# Altium schematic sheet Linux_Card.SchDoc
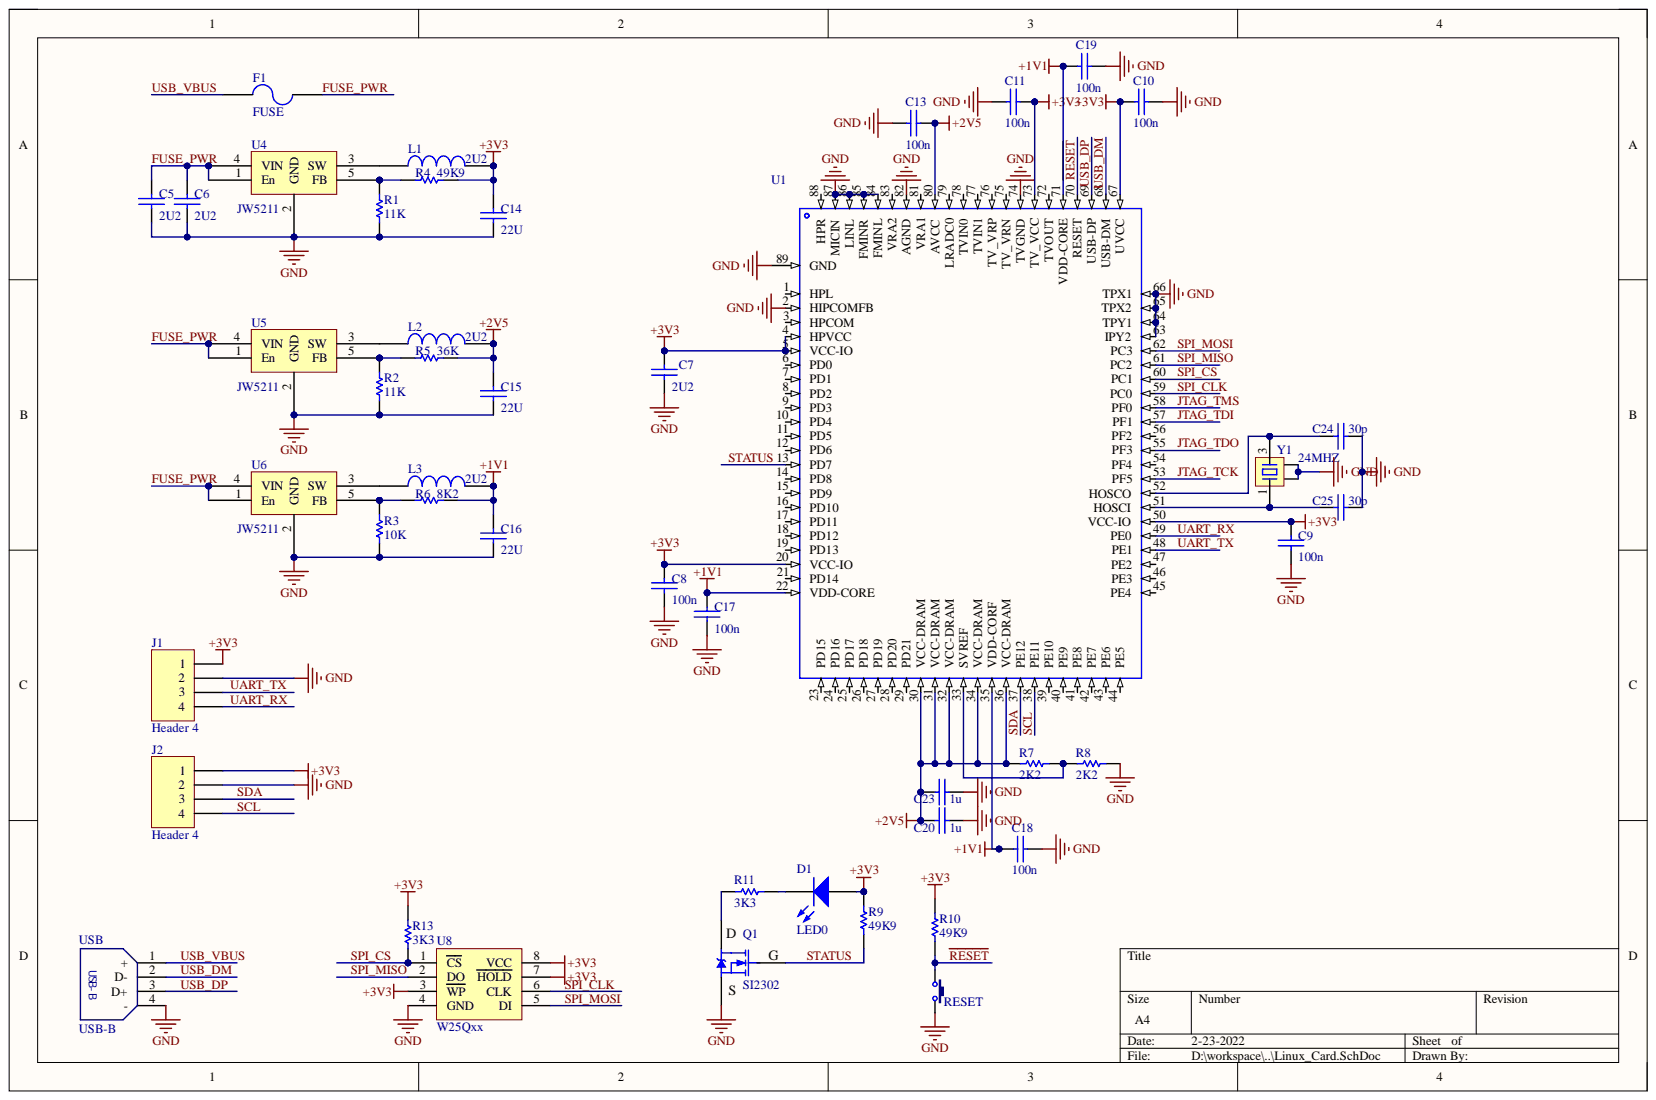
[diagram p0: Linux_Card.SchDoc]

## Parts
C5  2U2  [0603C]
C6  2U2  [0603C]
C7  2U2  [0603C]
C8  100n  [0603C]
C9  100n  [0603C]
C10  100n  [0603C]
C11  100n  [0603C]
C13  100n  [0603C]
C14  22U  [0603C]
C15  22U  [0603C]
C16  22U  [0603C]
C17  100n  [0603C]
C18  100n  [0603C]
C19  100n  [0603C]
C20  1u  [0603C]
C23  1u  [0603C]
C24  30p  [0603C]
C25  30p  [0603C]
D1  LED0  [LED 0805G]
F1  FUSE  [FU 0805]
J1  Header 4  [Uart]
J2  Header 4  [Uart]
L1  2U2  [0805L]
L2  2U2  [0805L]
L3  2U2  [0805L]
Q1  SI2302  [SOT23-3N]
R1  11K  [0603R]
R2  11K  [0603R]
R3  10K  [0603R]
R4  49K9  [0603R]
R5  36K  [0603R]
R6  8K2  [0603R]
R7  2K2  [0603R]
R8  2K2  [0603R]
R9  49K9  [0603R]
R10  49K9  [0603R]
R11  3K3  [0603R]
R13  3K3  [0603R]
RESET  3x6x5  [TSW SMD-3*6*2.5]  (value not drawn)
U1  (no value)  [QFN-88_L10.0-W10.0-P0.40-BL-EP6.75]
U4  JW5211  [SOT23-5N]
U5  JW5211  [SOT23-5N]
U6  JW5211  [SOT23-5N]
U8  W25Qxx  [SOP8W_N]
USB  USB-B  [USB]
Y1  24MHZ  [OSC 3225-4P]

## Nets
+1V1: C16.2 C17.2 C18.2 C19.2 L3.2 R6.1 U1.22(VDD-CORE) U1.35(VDD-CORF) U1.71(VDD-CORE)
+2V5: C13.2 C15.2 C20.2 C23.2 L2.2 R5.1 R7.1 U1.30(VCC-DRAM) U1.31(VCC-DRAM) U1.32(VCC-DRAM) U1.34(VCC-DRAM) U1.36(VCC-DRAM) U1.80(AVCC)
+3V3: C7.2 C8.2 C9.2 C10.2 C11.2 C14.2 D1.1 J1.1 J2.1 L1.2 R4.1 R9.2 R10.2 R13.2 U1.4(HPVCC) U1.5(VCC-IO) U1.20(VCC-IO) U1.50(VCC-IO) U1.67(UVCC) U1.73(TV_VCC) U8.3(WP) U8.7(HOLD) U8.8(VCC)
GND: C5.1 C6.1 C7.1 C8.1 C9.1 C10.1 C11.1 C13.1 C14.1 C15.1 C16.1 C17.1 C18.1 C19.1 C20.1 C23.1 C24.1 C25.1 J1.2 J2.2 Q1.2 R1.1 R2.1 R3.1 R8.2 RESET.2 U1.2(HIPCOMFB) U1.63(IPY2) U1.64(TPY1) U1.65(TPX2) U1.66(TPX1) U1.74(TVGND) U1.82(AGND) U1.84(FMINL) U1.85(FMINR) U1.86(LINL) U1.87(MICIN) U1.89(GND) U4.2(GND) U5.2(GND) U6.2(GND) U8.4(GND) USB.4(-) Y1.2 Y1.4
~{RESET}: R10.1 RESET.1 U1.70(RESET)
FUSE_PWR: C5.2 C6.2 F1.2 U4.1(En) U4.4(VIN) U5.1(En) U5.4(VIN) U6.1(En) U6.4(VIN)
JTAG_TCK: U1.53(PF5)
JTAG_TDI: U1.57(PF1)
JTAG_TDO: U1.55(PF3)
JTAG_TMS: U1.58(PF0)
SCL: J2.4 U1.38(PE11)
SDA: J2.3 U1.37(PE12)
SPI_CLK: U1.59(PC0) U8.6(CLK)
SPI_CS: R13.1 U1.60(PC1) U8.1(CS)
SPI_MISO: U1.61(PC2) U8.2(DO)
SPI_MOSI: U1.62(PC3) U8.5(DI)
STATUS: Q1.1 R9.1 U1.13(PD7)
UART_RX: J1.4 U1.49(PE0)
UART_TX: J1.3 U1.48(PE1)
USB_DM: U1.68(USB-DM) USB.2(D-)
USB_DP: U1.69(USB-DP) USB.3(D+)
USB_VBUS: F1.1 USB.1(+)
NetC24_2: C24.2 U1.52(HOSCO) Y1.3
NetC25_2: C25.2 U1.51(HOSCI) Y1.1
NetD1_2: D1.2 R11.1
NetL1_1: L1.1 U4.3(SW)
NetL2_1: L2.1 U5.3(SW)
NetL3_1: L3.1 U6.3(SW)
NetQ1_3: Q1.3 R11.2
NetR1_2: R1.2 R4.2 U4.5(FB)
NetR2_2: R2.2 R5.2 U5.5(FB)
NetR3_2: R3.2 R6.2 U6.5(FB)
NetR7_2: R7.2 R8.1 U1.33(SVREF)

Not connected: U1 1(HPL) 3(HPCOM) 6(PD0) 7(PD1) 8(PD2) 9(PD3) 10(PD4) 11(PD5) 12(PD6) 14(PD8) 15(PD9) 16(PD10) 17(PD11) 18(PD12) 19(PD13) 21(PD14) 23(PD15) 24(PD16) 25(PD17) 26(PD18) 27(PD19) 28(PD20) 29(PD21) 39(PE10) 40(PE9) 41(PE8) 42(PE7) 43(PE6) 44(PE5) 45(PE4) 46(PE3) 47(PE2) 54(PF4) 56(PF2) 72(TVOUT) 75(TV_VRN) 76(TV_VRP) 77(TVIN1) 78(TVIN0) 79(LRADC0) 81(VRA1) 83(VRA2) 88(HPR)

## Library data of the parts
C5-C11 C13-C20 C23-C25 = CAP: 无极性电容; Component Kind: Standard; Library Name: Linux_Card.SchLib
D1 = LED0: Typical INFRARED GaAs LED; Component Kind: Standard; LatestRevisionDate: 08-Jul-2005; LatestRevisionNote: Mech Layer 1 removed.; Library Name: Miscellaneous Devices.IntLib; PackageDescription: LED; 2 Leads; PackageReference: LED-0; Published: 8-Jun-2000; Simulation: LED0; Publisher: Altium Limited
J1 J2 = Header 4: Header, 4-Pin; Component Kind: Standard; LatestRevisionDate: 17-Jul-2002; LatestRevisionNote: Re-released for DXP Platform.; Library Name: Miscellaneous Connectors.IntLib; Signal Integrity: Connector; Publisher: Altium Limited
L1-L3 = INDUCTOR: Component Kind: Standard; Description: INDUCTOR; Library Name: Linux_Card.SchLib
Q1 = SI2302: N沟道场效应管; 版权归属: 源创客; BOM名称: N沟道场效应管; Component Kind: Standard; 学习资源: www.ooocke.com; Library Name: Linux_Card.SchLib
R1-R11 R13 = RES: Component Kind: Standard; Library Name: Linux_Card.SchLib
RESET = TSW 3x6: 3x6轻触开关; 版权归属: 源创客; BOM名称: 3x6轻触开关; Component Kind: Standard; 学习资源: www.ooocke.com; Library Name: Linux_Card.SchLib
U1 = F1C200S: Add into BOM: yes; Component Kind: Standard; Convert to PCB: yes; Datasheet: https://atta.szlcsc.com/upload/public/pdf/source/20210820/C2879851_9ABBD192FDB498091135F4F0D1E01FA0.pdf; Device: F1C200S; JLCPCB On Sale: 1; JLCPCB Part Class: Extended Part; JLCPCB Stock: 0; LCSC On Sale: 0; LCSC Part Name: F1C200S; LCSC Stock: 0; Manufacturer Part: F1C200S; PCB3D: QFN-88_L10.0-W10.0-H0.8-P0.40-BL-EP; Price: 0; Supplier: LCSC; Supplier Footprint: QFN-88; Supplier Part: C2879851; Symbol: F1C200S
U8 = W25Qxx: 外置Flash; 版权归属: 源创客; BOM名称: 外置Flash; Component Kind: Standard; 学习资源: www.ooocke.com; Library Name: Linux_Card.SchLib
Y1 = XTAL-4P: 4脚无源晶振; Component Kind: Standard; Library Name: Linux_Card.SchLib
F1 = FUSE: Component Kind: Standard; Library Name: Linux_Card.SchLib
U4-U6 = JW5211: Component Kind: Standard; Library Name: Linux_Card.SchLib
USB = USB-B: Component Kind: Standard; Library Name: Linux_Card.SchLib
